# Altium schematic sheet USB Audio.SchDoc("USB Audio")
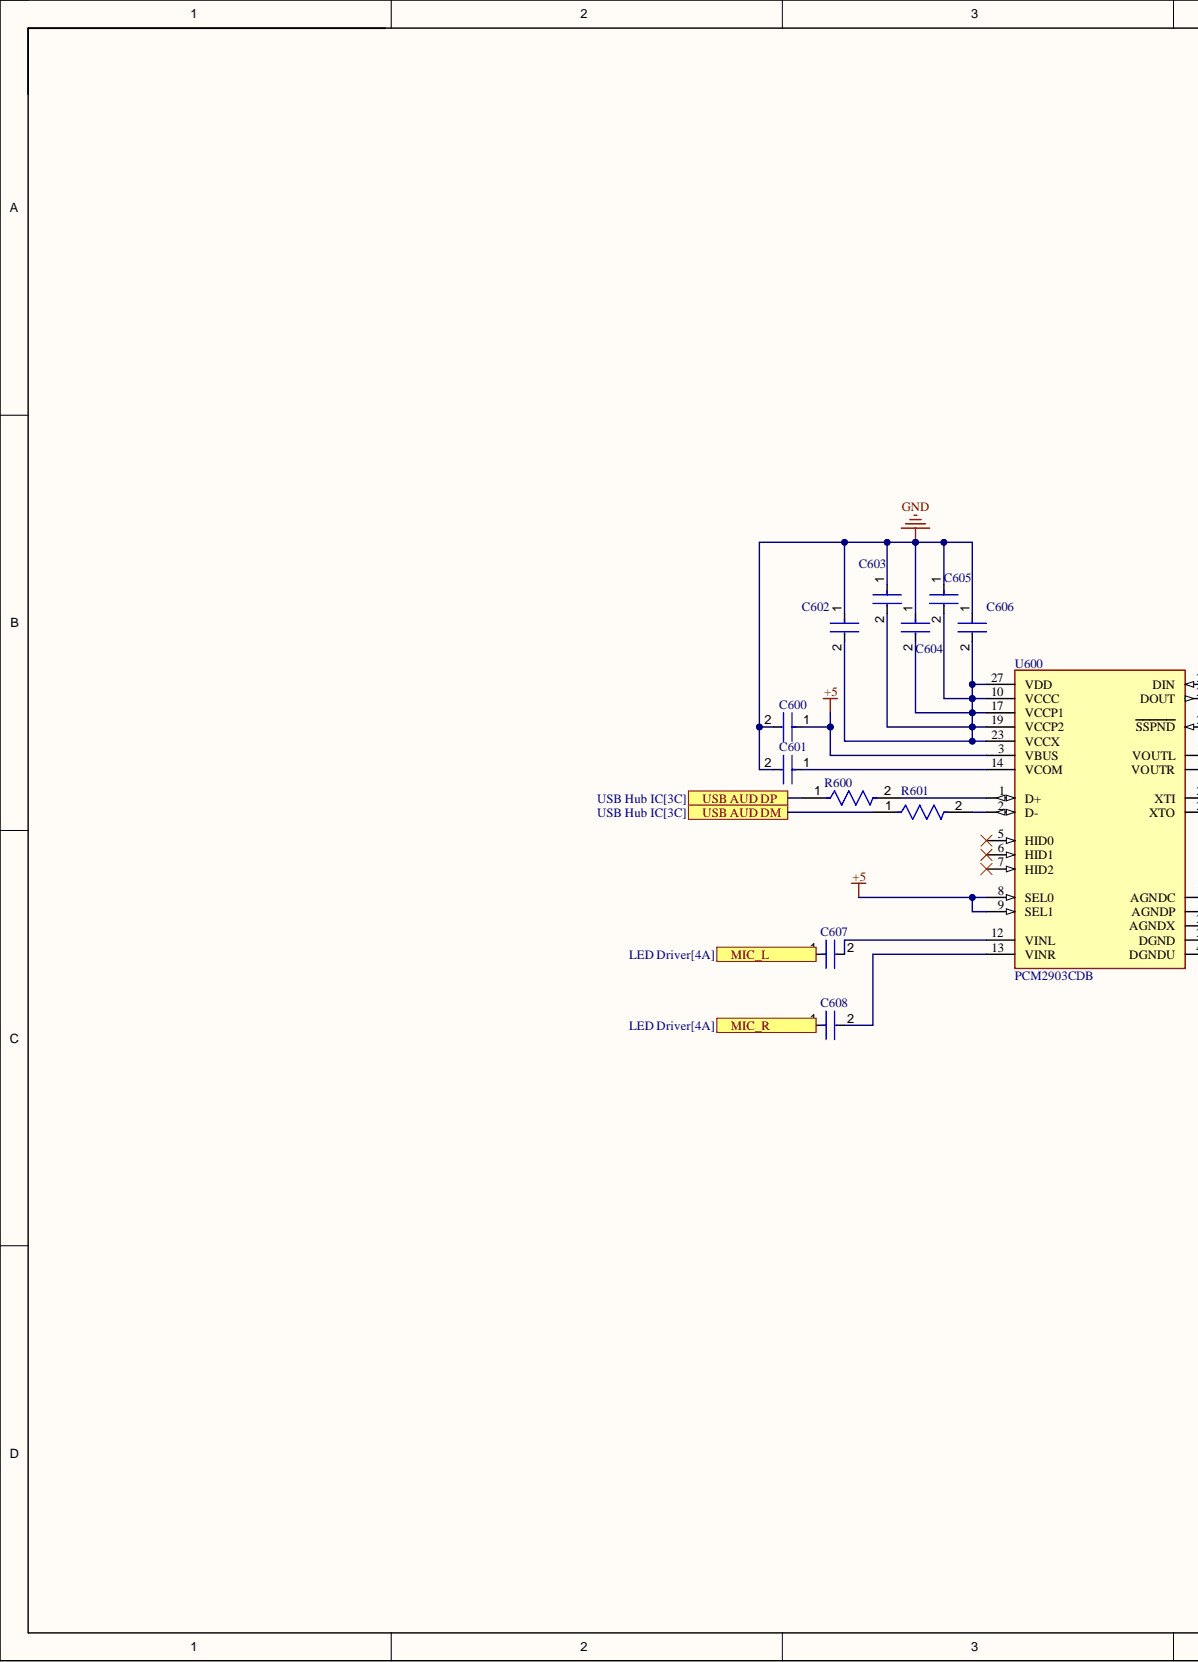
[diagram p0: USB Audio.SchDoc, left]
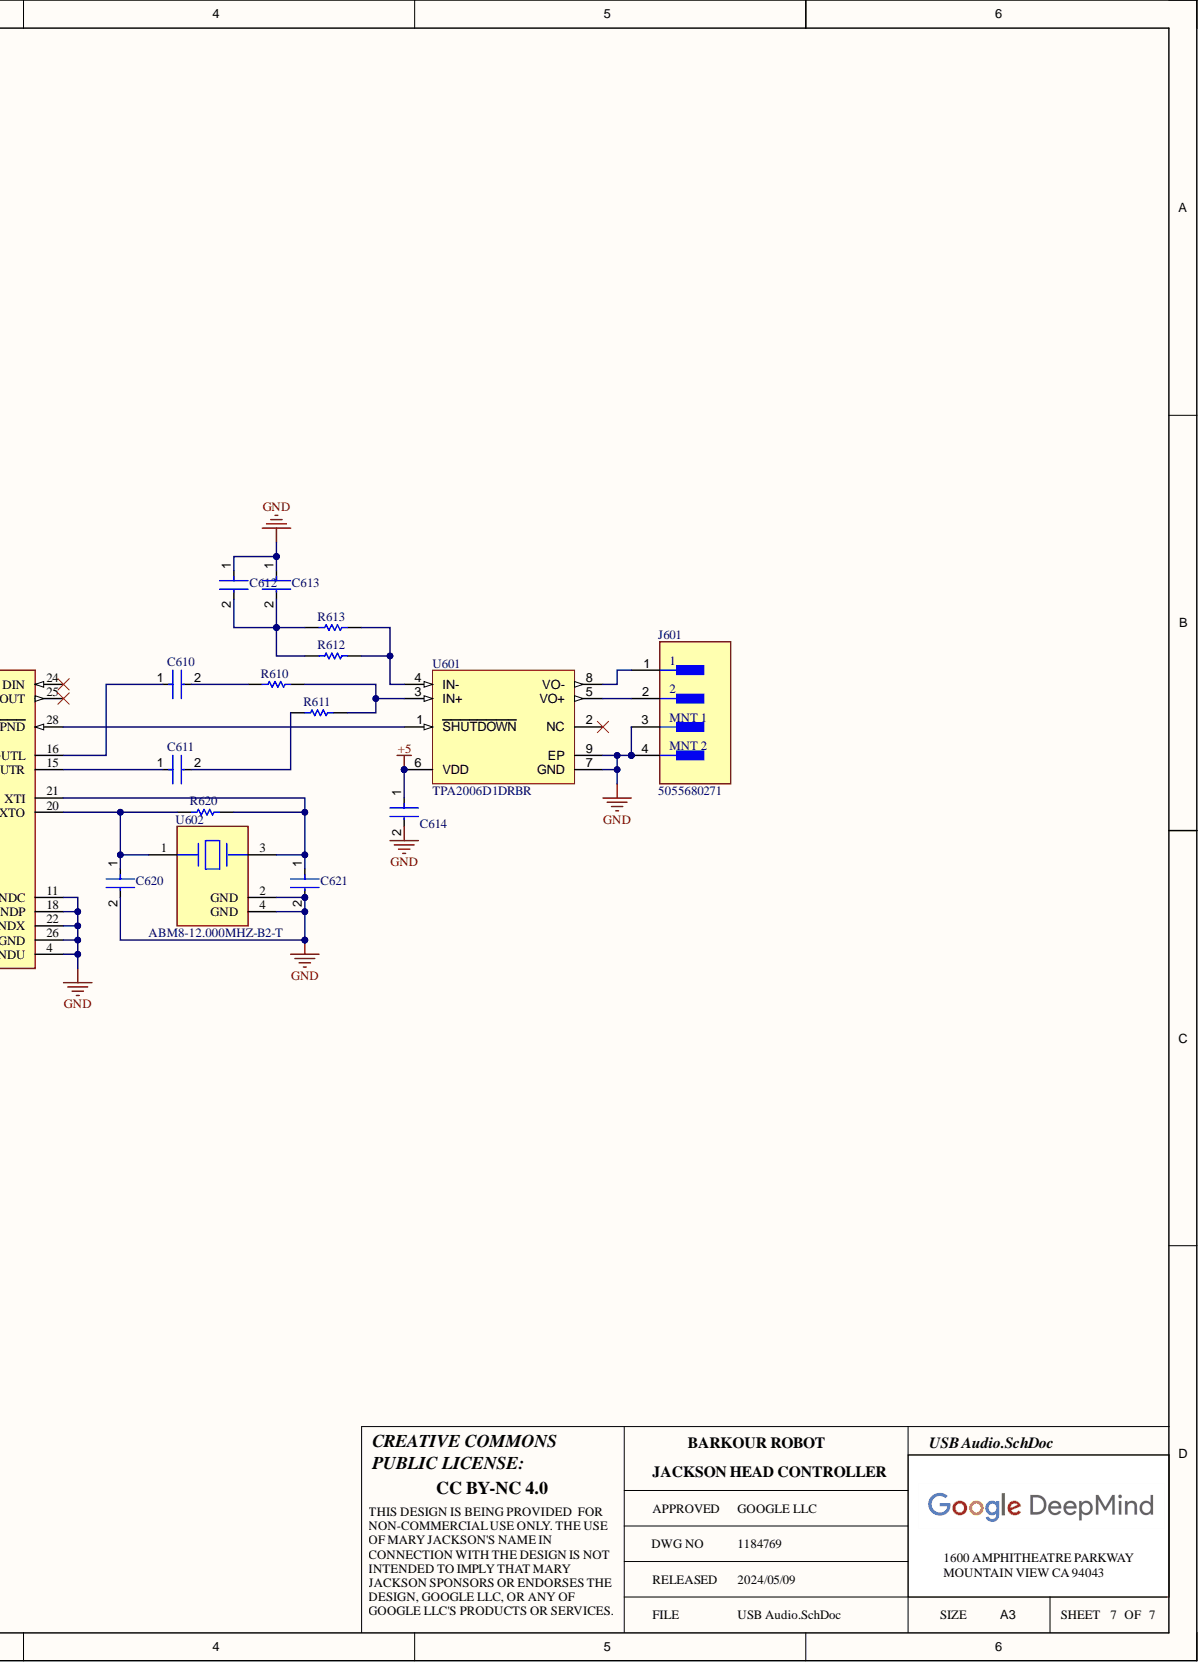
[diagram p1: USB Audio.SchDoc, right]

## Parts
C600  GRM155R70J105KA12D  [FP-GRM155-0_05-MFG]  (value not drawn)
C601  GRM155R70J105KA12D  [FP-GRM155-0_05-MFG]  (value not drawn)
C602  GRM155R70J105KA12D  [FP-GRM155-0_05-MFG]  (value not drawn)
C603  GRM155R70J105KA12D  [FP-GRM155-0_05-MFG]  (value not drawn)
C604  GRM155R70J105KA12D  [FP-GRM155-0_05-MFG]  (value not drawn)
C605  GRM155R70J105KA12D  [FP-GRM155-0_05-MFG]  (value not drawn)
C606  GRM155R70J105KA12D  [FP-GRM155-0_05-MFG]  (value not drawn)
C607  C0402C103K3RACTU  [FP-C0402-BB-MFG]  (value not drawn)
C608  C0402C103K3RACTU  [FP-C0402-BB-MFG]  (value not drawn)
C610  C0402C103K3RACTU  [FP-C0402-BB-MFG]  (value not drawn)
C611  C0402C103K3RACTU  [FP-C0402-BB-MFG]  (value not drawn)
C612  C0402C103K3RACTU  [FP-C0402-BB-MFG]  (value not drawn)
C613  C0402C103K3RACTU  [FP-C0402-BB-MFG]  (value not drawn)
C614  GRM155R70J105KA12D  [FP-GRM155-0_05-MFG]  (value not drawn)
C620  GJM1555C1H180JB01D  [FP-GJM155-0_05-IPC_B]  (value not drawn)
C621  GJM1555C1H180JB01D  [FP-GJM155-0_05-IPC_B]  (value not drawn)
J601  5055680271  [FP-5055680271-MFG]
R600  ERJ-2RKF27R0X  [FP-ERJ2R-IPC_B]  (value not drawn)
R601  ERJ-2RKF27R0X  [FP-ERJ2R-IPC_B]  (value not drawn)
R610  ERA2AEB683X  [RESC1005X40X25LL10T10]  (value not drawn)
R611  ERA2AEB683X  [RESC1005X40X25LL10T10]  (value not drawn)
R612  ERA2AEB683X  [RESC1005X40X25LL10T10]  (value not drawn)
R613  ERA2AEB683X  [RESC1005X40X25LL10T10]  (value not drawn)
R620  ERJ-2RKF1004X  [RESC1005X40X25LL05T05]  (value not drawn)
U600  PCM2903CDB  [FP-DB0028A-IPC_A]
U601  TPA2006D1DRBR  [DRB0008A_V]
U602  ABM8-12.000MHZ-B2-T  [FP-ABM8-MFG]

## Ports
MIC_L, MIC_R, USB AUD DM, USB AUD DP

## Nets
+5: C600.1 C614.1 U600.3(VBUS) U600.8(SEL0) U600.9(SEL1) U601.6(VDD)
GND: C600.2 C601.2 C602.1 C603.1 C604.1 C605.1 C606.1 C612.1 C613.1 C614.2 C620.2 C621.2 J601.3 J601.4 U600.4(DGNDU) U600.11(AGNDC) U600.18(AGNDP) U600.22(AGNDX) U600.26(DGND) U601.7(GND) U601.9(EP) U602.2(GND) U602.4(GND)
MIC_L: C607.1 ; port MIC_L
MIC_R: C608.1 ; port MIC_R
USB AUD DM: R601.1 ; port USB AUD DM
USB AUD DP: R600.1 ; port USB AUD DP
NetC601_1: C601.1 U600.14(VCOM)
NetC602_2: C602.2 C603.2 C604.2 C605.2 C606.2 U600.10(VCCC) U600.17(VCCP1) U600.19(VCCP2) U600.23(VCCX) U600.27(VDD)
NetC607_2: C607.2 U600.12(VINL)
NetC608_2: C608.2 U600.13(VINR)
NetC610_1: C610.1 U600.16(VOUTL)
NetC610_2: C610.2 R610.1
NetC611_1: C611.1 U600.15(VOUTR)
NetC611_2: C611.2 R611.1
NetC612_2: C612.2 C613.2 R612.1 R613.1
NetC620_1: C620.1 R620.1 U600.20(XTO) U602.1
NetC621_1: C621.1 R620.2 U600.21(XTI) U602.3
NetJ601_1: J601.1 U601.8(VO-)
NetJ601_2: J601.2 U601.5(VO+)
NetR600_2: R600.2 U600.1(D+)
NetR601_2: R601.2 U600.2(D-)
NetR610_2: R610.2 R611.2 U601.3(IN+)
NetR612_2: R612.2 R613.2 U601.4(IN-)
NetU600_28: U600.28(SSPND) U601.1(SHUTDOWN)

Not connected: U600 5(HID0) 6(HID1) 7(HID2) 24(DIN) 25(DOUT); U601 2(NC)

## Library data of the parts
C600-C606 C614 = CMP-2008-04587-2: Chip Multilayer Ceramic Capacitors for General Purpose, 0402, 1.0uF, X7R, 15%, 10%, 6.3V; Capacitance: 1uF; Case Code (Imperial): 0402; Case Code (Metric): 1005; Case/Package: 0402; Component Kind: Standard; Depth: 0.5mm; Dielectric: X7R; Height: 0.5mm; Lead Free: Lead Free; Length: 1mm; Library Name: Altium Content Vault; Material: Ceramic; Max Operating Temperature: 125°C; Min Operating Temperature: -55°C; Mount: Surface Mount; Package Quantity: 10000; Packaging: Tape and Reel; Termination: SMD/SMT; Thickness: 0.022inch; Tolerance: 10%; Voltage Rating: 6.3V; Voltage Rating (DC): 6.3V; Weight: 0.000212oz; Width: 0.5mm
C607 C608 C610-C613 = CMP-2008-03070-3: Multilayer Ceramic Capacitors MLCC - SMD/SMT 25volts 10000pF X7R 10%; Manufacturer: Kemet; Capacitance: 10,000 pF; Temperature Coefficient: 15%; Tolerance: 0.1; Value: 10,000 pF; Voltage Rating: 25 V; Automotive: No; Category: Cap; Component Kind: Standard; ComponentLink2Description: Datasheet; ComponentLink2URL: https://content.kemet.com/datasheets/KEM_C1002_X7R_SMD.pdf; Device Class L1: Passive Components; Device Class L2: Capacitors; Device Class L3: Ceramic Capacitors; Digikey Description: Multilayer Ceramic Capacitors MLCC - SMD/SMT 25volts 10000pF X7R 10%; Height: 0.55mm; Ipc Land Pattern Name: CAPC10050X50; Lead Free: Yes; Library Name: Altium Content Vault; Manufacturer Part Number: C0402C103K3RACTU; Material: Ceramic; Octopart: https://octopart.com/c0402c103k3ractu-kemet-129636; Package: C0402-BB; RoHS: TRUE; Temperature: -55°C to +125°C; Temperature Characteristic: X7R; Temperature Range High: +125°C; Temperature Range Low: -55°C
C620 C621 = CMP-2008-03807-2: Multi-Layer Ceramic Capacitor 18pF C0G  ±5% 0402 Paper T/R; Voltage: 50V; Capacitance: 18pF; Case Code (Imperial): 0402; Case Code (Metric): 1005; Case/Package: 0402; Component Kind: Standard; Depth: 0.5mm; Dielectric: C0G; Height: 0.5mm; Lead Free: Lead Free; Length: 1mm; Library Name: Altium Content Vault; Max Operating Temperature: 125°C; Min Operating Temperature: -55°C; Mount: Surface Mount; Package Quantity: 1; Packaging: Tape and Reel; Radiation Hardening: No; Termination: SMD/SMT; Thickness: 0.022inch; Tolerance: 5%; Voltage Rating: 50V; Voltage Rating (DC): 50V; Width: 0.02inch
J601 = CMP-04776-000038-1: CONN HEADER SMD 2POS 1.25MM; Manufacturer: molex; Conn Gender: Male; Conn Orientation: Vertical; Current Rating: 1.5A; Number Of Contacts: 2; Voltage Rating: 50V; Automotive: No; Category: Conn; Component Kind: Standard; ComponentLink2Description: Datasheet; ComponentLink2URL: https://www.molex.com/webdocs/datasheets/pdf/en-us/5055680271_PCB_HEADERS.pdf; Contact Material: Tin,Nickel; Device Class L1: Connectors; Device Class L2: Headers and Wire Housings; Device Class L3: unset; Digikey Description: CONN HEADER SMD 2POS 1.25MM; Footprint Url: https://www.molex.com/pdm_docs/sd/5055680271_sd.pdf; Height: 4.4mm; Lead Free: Yes; Library Name: Altium Content Vault; Manufacturer Part Number: 5055680271; Number Of Rows: 1; Octopart: https://octopart.com/505568-0271-molex-84869617; Package: 0; Pitch: 1.25mm; RoHS: TRUE; Temperature: -40°C to +105°C; Temperature Range High: +105°C; Temperature Range Low: -40°C
R600 R601 = CMP-2002-00830-2: RES SMD 27 OHM 1% 1/10W 0402; Max Power Dissipation: 100mW; Resistance: 27R; Temperature Coefficient: 100ppm/°C; Voltage Rating: 50V; Case Code (Imperial): 0402; Case Code (Metric): 1005; Case/Package: 0402; Component Kind: Standard; Construction: Rectangular; Contact Plating: Tin; Depth: 0.5mm; Features: Automotive AEC-Q200; Height: 0.35mm; Lead Free: Lead Free; Length: 1mm; Library Name: Altium Content Vault; Max Operating Temperature: 155°C; Military Standard: Not; Min Operating Temperature: -55°C; Mount: Surface Mount; Number of Pins: 2; Number of Terminations: 2; Package Quantity: 1; Packaging: Tape and Reel; Power Rating: 100mW; Radiation Hardening: No; REACH SVHC: No SVHC; Resistance Tolerance: 1%; Resistor Type: Thick Film; RoHS Compliant: Yes; Series: ERJ; Technology: THICK FILM; Termination: SMD/SMT; Tolerance: 1%; Voltage Rating (DC): 50V; Weight: 2.8E-05oz; Width: 0.5mm
R610-R613 = CMP-2002-05893-1: Manufacturer: Panasonic; Component Kind: Standard; Library Name: Altium Content Vault; Part Number: ERA2AEB683X
R620 = CMP-2002-00609-3: Chip Resistor, 1 MOhm, +/- 1%, 100 mW, -55 to 155 degC, 0402 (1005 Metric), RoHS, Tape and Reel; Manufacturer: Basic Passives; Case\/Package: 0402; Power Rating: 100 mW; Resistance: 1 MOhm; Voltage Rating: 50 V; Case Code (Imperial): 0402; Case Code (Metric): 1005; Component Kind: Standard; ComponentLink1Description: Manufacturer URL; ComponentLink1URL: http://www.panasonic.com/; ComponentLink2Description: Datasheet; ComponentLink2URL: http://industrial.panasonic.com/cdbs/www-data/pdf/RDA0000/AOA0000C86.pdf; DatasheetVersion: 04/2015; Height: 0.35 mm; Length: 1 mm; Library Name: Altium Content Vault; Max Operating Temperature: 155 degC; Min Operating Temperature: -55 degC; Mount: Surface Mount; Mounting Technology: Surface Mount; Package Quantity: 10000; PackageDescription: 2-Pin Surface Mount Device, Body 1 x 0.5 mm; PackageReference: 0402; Packaging: Tape and Reel; PartNumber: ERJ-2RKF1004X; Pins: 2; Radiation Hardening: No; REACH SVHC: Yes; RoHSCompliant: true; Tolerance: 1%; Weight: 2.8E-05oz; Width: 0.5 mm
U600 = CMP-04873-000010-1: IC STERO AUD CODEC W/USB 28SSOP; Interface: USB; Max Supply Voltage: 3.6V; Min Supply Voltage: 3V; Nominal Supply Current: 70mA; Number of A/D Converters: 2; Operating Supply Voltage: 3.3V; Resolution: 16b; Sampling Rate: 48KS/s; Signal to Noise Ratio (SNR): 89dB; Case/Package: SSOP; Component Kind: Standard; Lead Free: Lead Free; Library Name: Altium Content Vault; Max Operating Temperature: 85°C; Min Operating Temperature: -25°C; Mount: Surface Mount; Number of ADC Channels: 2; Number of Analog Inputs: 2; Number of Analog Outputs: 2; Number of D/A Converters: 2; Number of DAC Channels: 2; Number of Pins: 28; Package Quantity: 50; Packaging: Rail/Tube; Radiation Hardening: No; REACH SVHC: No SVHC; RoHS Compliant: Yes; Series: -; Supply Current: 70mA
U601 = CMP-0991-00129-2: 1.45-W Mono Class-D Audio Amplifier with 1.8 V Compatible Shutdown Voltage, -40 to 85 degC, 8-pin SON (DRB8), Green (RoHS & no Sb/Br); Manufacturer: Texas Instruments; Output Power(W): 1.45; PSRR(dB): -75; SubFamily: Dynamic Speaker; Supply Max(Max)(Volt): 5.5; Supply Min(Min)(Volt): 2.5; Component Kind: Standard; ComponentLink1Description: Manufacturer URL; ComponentLink1URL: http://www.ti.com/; ComponentLink2Description: Datasheet; ComponentLink2URL: http://www.ti.com/general/docs/lit/getliterature.tsp?genericPartNumber=TPA2006D1&fileType=pdf; ComponentLink3Description: Package Specification; ComponentLink3URL: http://www.ti.com/litv/pdf/mpds118h; DatasheetVersion: SLOS498A; Eco-Plan: Green (RoHS & no Sb/Br); Library Name: Altium Content Vault; Mounting Technology: Surface Mount; PackageDescription: 8-Pin Plastic Small Outline, Body 3 x 3 mm, Pitch 0.65 mm; PackageReference: DRB0008A; PackageVersion: 4203482-2/K, 06/2012; PartNumber: TPA2006D1DRBR; Speaker Amp Type: Class-D; Speaker Channels: Mono
U602 = CMP-27762-003220-1: Crystal 12MHz ±20ppm 18pF SMD-4 3.2mm x 2.5mm; ESR (Equivalent Series Resistance): 120R; Frequency Stability: 50ppm; Case/Package: CSMD; Component Kind: Standard; Depth: 2.5mm; Frequency: 12MHz; Frequency Tolerance: 20ppm; Height: 0.031inch; Lead Free: Lead Free; Length: 3.2mm; Library Name: Altium Content Vault; Load Capacitance: 18pF; Max Operating Temperature: 70°C; Min Operating Temperature: -20°C; Mount: Surface Mount; Number of Pins: 4; Operating Frequency: 12 MHz; Package Quantity: 1000; Packaging: Tape and Reel; Radiation Hardening: No; REACH SVHC: No SVHC; Resistor Type: Ceramic; RoHS Compliant: Yes; Series: ABM8; Series Resistance: 120R; Termination: SMD/SMT; Tolerance: 20ppm; Width: 0.098inch
